# Altium schematic sheet ARK_CAN_GPS_Microcontroller.SchDoc(ARK_CAN_GPS_Microcontroller)
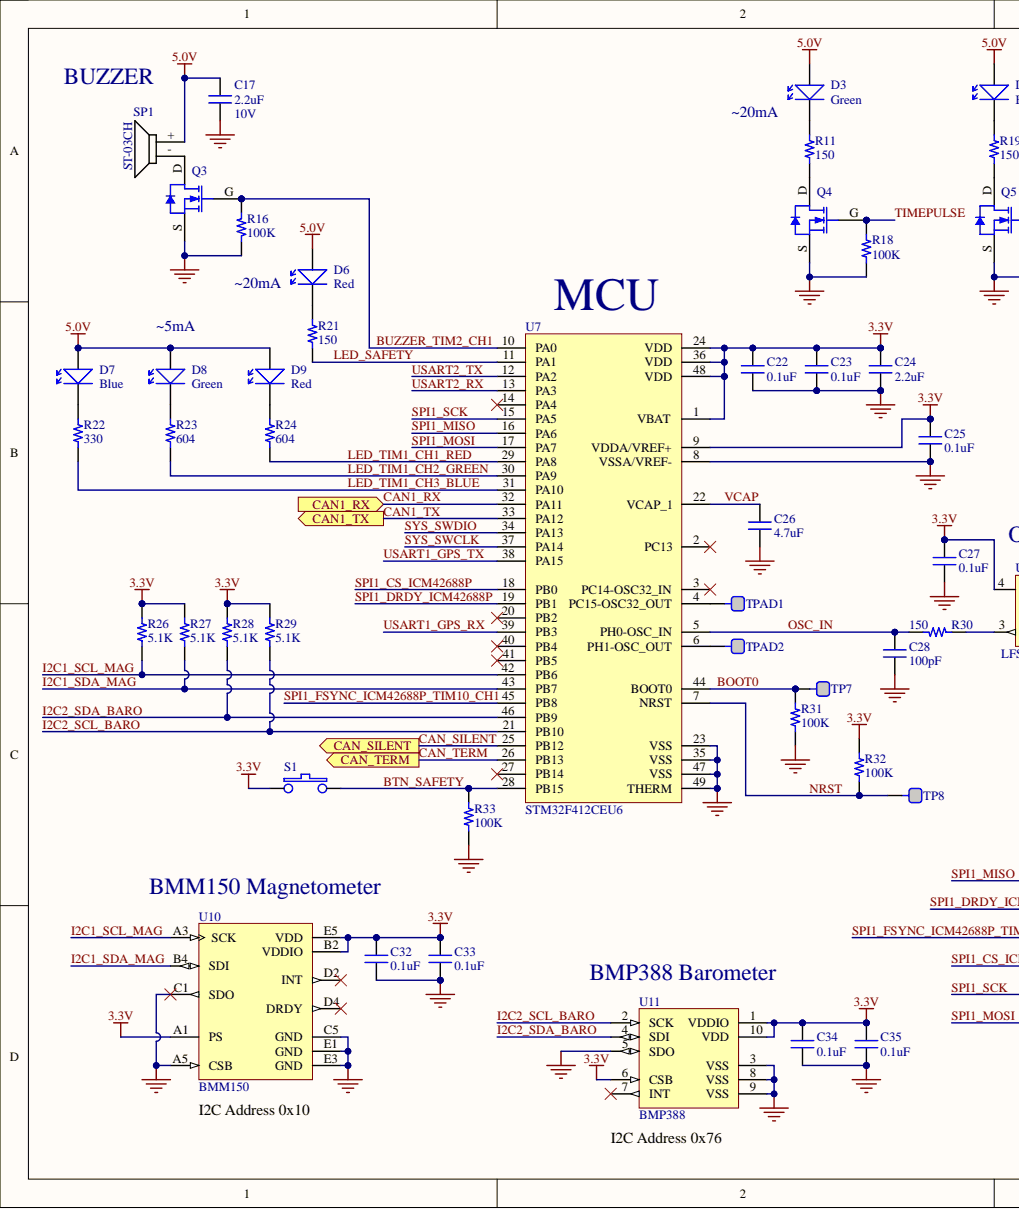
[diagram p0: ARK_CAN_GPS_Microcontroller.SchDoc, left]
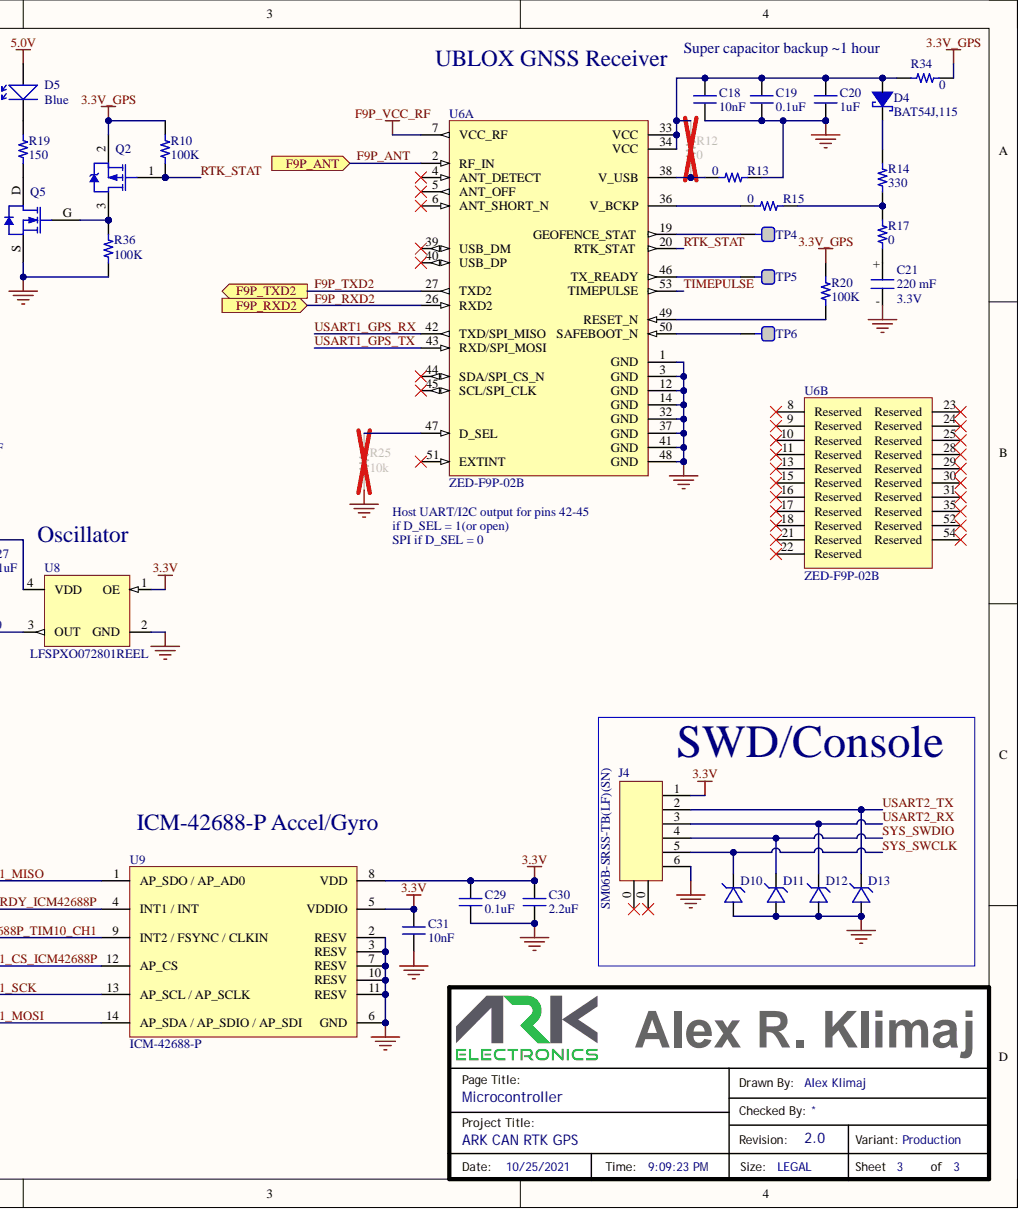
[diagram p1: ARK_CAN_GPS_Microcontroller.SchDoc, right]

## Parts
C17  2.2uF  Voltage_Rating: 10V  [CAP 0402/1005]
C18  value 10nF  [CAP 0402/1005]
C19  value 0.1uF  [CAP 0402/1005]
C20  1uF  [CAP 0402/1005]
C21  220 mF  Voltage_Rating: 3.3V  [DSK-3R3H224U-HL]
C22  value 0.1uF  [CAP 0402/1005]
C23  value 0.1uF  [CAP 0402/1005]
C24  2.2uF  [CAP 0402/1005]
C25  value 0.1uF  [CAP 0402/1005]
C26  4.7uF  [CAP 0402/1005]
C27  value 0.1uF  [CAP 0402/1005]
C28  100pF  [CAP 0402/1005]
C29  value 0.1uF  [CAP 0402/1005]
C30  2.2uF  [CAP 0402/1005]
C31  value 10nF  [CAP 0402/1005]
C32  value 0.1uF  [CAP 0402/1005]
C33  value 0.1uF  [CAP 0402/1005]
C34  value 0.1uF  [CAP 0402/1005]
C35  value 0.1uF  [CAP 0402/1005]
D3  LTST-C191KGKT  [LTST-C191TBKT]  (value not drawn)
D4  value BAT54J,115  Manufacturer Part Number: BAT54J,115  [SOD323]
D5  LTST-C194TBKT  [LTST-C191TBKT]  (value not drawn)
D6  LTST-C190KRKT  [LTST-C191TBKT]  (value not drawn)
D7  LTST-C194TBKT  [LTST-C191TBKT]  (value not drawn)
D8  LTST-C191KGKT  [LTST-C191TBKT]  (value not drawn)
D9  LTST-C190KRKT  [LTST-C191TBKT]  (value not drawn)
D10  (no value)  [SOD-923]
D11  (no value)  [SOD-923]
D12  (no value)  [SOD-923]
D13  (no value)  [SOD-923]
J4  value SM06B-SRSS-TB(LF)(SN)  [SM06B-SRSS-TB(LF)(SN)]
Q2  (no value)  [INF-PG-SOT-323_L]
Q3  DMN53D0L-7  [SOT23 DIODES]  (value not drawn)
Q4  DMN53D0L-7  [SOT23 DIODES]  (value not drawn)
Q5  DMN53D0L-7  [SOT23 DIODES]  (value not drawn)
R10  100K  [RESC0402(1005)_N]
R11  150  [RESC0402(1005)_N]
R12  0  [RESC0402(1005)_N]
R13  0  [RESC0402(1005)_N]
R14  330  [RESC0402(1005)_N]
R15  0  [RESC0402(1005)_N]
R16  100K  [RESC0402(1005)_N]
R17  0  [RESC0402(1005)_N]
R18  100K  [RESC0402(1005)_N]
R19  150  [RESC0402(1005)_N]
R20  100K  [RESC0402(1005)_N]
R21  150  [RESC0402(1005)_N]
R22  330  [RESC0402(1005)_N]
R23  604  [RESC0402(1005)_N]
R24  604  [RESC0402(1005)_N]
R25  value 10k  [RESC0402(1005)_N]
R26  value 5.1K  [RESC0402(1005)_N]
R27  value 5.1K  [RESC0402(1005)_N]
R28  value 5.1K  [RESC0402(1005)_N]
R29  value 5.1K  [RESC0402(1005)_N]
R30  150  [RESC0402(1005)_N]
R31  100K  [RESC0402(1005)_N]
R32  100K  [RESC0402(1005)_N]
R33  100K  [RESC0402(1005)_N]
R34  0  [RESC0402(1005)_N]
R36  100K  [RESC0402(1005)_N]
S1  PTS 647 SK38 SMTR2 LFS  [PTS 647 SK38 SMTR2 LFS]  (value not drawn)
SP1  ST-03CH  [ST-03CH]
TP4  (no value)  [TEST_PAD]  (no BOM)
TP5  (no value)  [TEST_PAD]  (no BOM)
TP6  (no value)  [TEST_PAD]  (no BOM)
TP7  (no value)  [TEST_PAD]  (no BOM)
TP8  (no value)  [TEST_PAD]  (no BOM)
TPAD1  (no value)  [TEST_PAD]  (no BOM)
TPAD2  (no value)  [TEST_PAD]  (no BOM)
U6  ZED-F9P-02B  [Ublox ZED-F9P]  (parts A,B)
U7  STM32F412CEU6  [UFQFPN48]
U8  LFSPXO072801REEL  Voltage_Rating: 3.3V  [LFSPXO072801REEL]
U9  ICM-42688-P  [ICM-42688-P]
U10  BMM150  [XDCR_BMM150]
U11  BMP388  [BMP388]

## Ports
CAN1_RX, CAN1_TX, CAN_SILENT, CAN_TERM, F9P_ANT, F9P_RXD2, F9P_TXD2

## Nets
3.3V: C22.2 C23.2 C24.2 C25.2 C27.2 C29.2 C30.2 C31.2 C32.2 C33.2 C34.2 C35.2 J4.1 R26.2 R27.2 R28.2 R29.2 R32.2 S1.2 U7.1(VBAT) U7.9(VDDA/VREF+) U7.24(VDD) U7.36(VDD) U7.48(VDD) U8.1(OE) U8.4(VDD) U9.5(VDDIO) U9.8(VDD) U10.A1(PS) U10.B2(VDDIO) U10.E5(VDD) U11.1(VDDIO) U11.6(CSB) U11.10(VDD)
3.3V_GPS: Q2.2 R10.2 R20.2 R34.1
5.0V: C17.2 D3.2 D5.2 D6.2 D7.2 D8.2 D9.2 SP1.+
F9P_VCC_RF: U6.7(VCC_RF)
GND: C17.1 C18.1 C19.1 C20.1 C21.- C22.1 C23.1 C24.1 C25.1 C26.1 C27.1 C28.1 C29.1 C30.1 C31.1 C32.1 C33.1 C34.1 C35.1 D10.2 D11.2 D12.2 D13.2 J4.6 Q3.S Q4.S Q5.S R13.2 R16.2 R18.1 R25.1 R31.1 R33.1 R36.1 U6.1(GND) U6.3(GND) U6.12(GND) U6.14(GND) U6.32(GND) U6.37(GND) U6.41(GND) U6.48(GND) U7.8(VSSA/VREF-) U7.23(VSS) U7.35(VSS) U7.47(VSS) U7.49(THERM) U8.2(GND) U9.2(RESV) U9.3(RESV) U9.6(GND) U9.7(RESV) U9.10(RESV) U9.11(RESV) U10.A5(CSB) U10.C1(SDO) U10.C5(GND) U10.E1(GND) U10.E3(GND) U11.3(VSS) U11.5(SDO) U11.8(VSS) U11.9(VSS)
BOOT0: R31.2 TP7.1 U7.44(BOOT0)
BTN_SAFETY: R33.2 S1.1 U7.28(PB15)
BUZZER_TIM2_CH1: Q3.G R16.1 U7.10(PA0)
CAN1_RX: U7.32(PA11) ; port CAN1_RX
CAN1_TX: U7.33(PA12) ; port CAN1_TX
CAN_SILENT: U7.25(PB12) ; port CAN_SILENT
CAN_TERM: U7.26(PB13) ; port CAN_TERM
F9P_ANT: U6.2(RF_IN) ; port F9P_ANT
F9P_RXD2: U6.26(RXD2) ; port F9P_RXD2
F9P_TXD2: U6.27(TXD2) ; port F9P_TXD2
I2C1_SCL_MAG: R26.1 U7.42(PB6) U10.A3(SCK)
I2C1_SDA_MAG: R27.1 U7.43(PB7) U10.B4(SDI)
I2C2_SCL_BARO: R29.1 U7.21(PB10) U11.2(SCK)
I2C2_SDA_BARO: R28.1 U7.46(PB9) U11.4(SDI)
LED_SAFETY: R21.1 U7.11(PA1)
LED_TIM1_CH1_RED: R24.1 U7.29(PA8)
LED_TIM1_CH2_GREEN: R23.1 U7.30(PA9)
LED_TIM1_CH3_BLUE: R22.1 U7.31(PA10)
NRST: R32.1 TP8.1 U7.7(NRST)
OSC_IN: C28.2 R30.1 U7.5(PH0-OSC_IN)
RTK_STAT: Q2.1 R10.1 U6.20(RTK_STAT)
SPI1_CS_ICM42688P: U7.18(PB0) U9.12(AP_CS)
SPI1_DRDY_ICM42688P: U7.19(PB1) U9.4(INT1_/_INT)
SPI1_FSYNC_ICM42688P_TIM10_CH1: U7.45(PB8) U9.9
SPI1_MISO: U7.16(PA6) U9.1(AP_SDO_/_AP_AD0)
SPI1_MOSI: U7.17(PA7) U9.14
SPI1_SCK: U7.15(PA5) U9.13(AP_SCL_/_AP_SCLK)
SYS_SWCLK: D10.1 J4.5 U7.37(PA14)
SYS_SWDIO: D11.1 J4.4 U7.34(PA13)
TIMEPULSE: Q4.G R18.2 U6.53(TIMEPULSE)
USART1_GPS_RX: U6.42(TXD/SPI_MISO) U7.39(PB3)
USART1_GPS_TX: U6.43(RXD/SPI_MOSI) U7.38(PA15)
USART2_RX: D12.1 J4.3 U7.13(PA3)
USART2_TX: D13.1 J4.2 U7.12(PA2)
VCAP: C26.2 U7.22(VCAP_1)
NetC18_2: C18.2 C19.2 C20.2 D4.2 R12.2 R34.2 U6.33(VCC) U6.34(VCC)
NetC21_+: C21.+ R17.1
NetD3_1: D3.1 R11.2
NetD4_1: D4.1 R14.2
NetD5_1: D5.1 R19.2
NetD6_1: D6.1 R21.2
NetD7_1: D7.1 R22.2
NetD8_1: D8.1 R23.2
NetD9_1: D9.1 R24.2
NetQ2_3: Q2.3 Q5.G R36.2
NetQ3_D: Q3.D SP1.-
NetQ4_D: Q4.D R11.1
NetQ5_D: Q5.D R19.1
NetR12_1: R12.1 R13.1 U6.38(V_USB)
NetR14_1: R14.1 R15.2 R17.2
NetR15_1: R15.1 U6.36(V_BCKP)
NetR20_1: R20.1 U6.49(RESET_N)
NetR25_2: R25.2 U6.47(D_SEL)
NetR30_2: R30.2 U8.3(OUT)
NetTP4_1: TP4.1 U6.19(GEOFENCE_STAT)
NetTP5_1: TP5.1 U6.46(TX_READY)
NetTP6_1: TP6.1 U6.50(SAFEBOOT_N)
NetTPAD1_1: TPAD1.1 U7.4(PC15-OSC32_OUT)
NetTPAD2_1: TPAD2.1 U7.6(PH1-OSC_OUT)

Not connected: J4 0; U6 4(ANT_DETECT) 5(ANT_OFF) 6(ANT_SHORT_N) 8(Reserved) 9(Reserved) 10(Reserved) 11(Reserved) 13(Reserved) 15(Reserved) 16(Reserved) 17(Reserved) 18(Reserved) 21(Reserved) 22(Reserved) 23(Reserved) 24(Reserved) 25(Reserved) 28(Reserved) 29(Reserved) 30(Reserved) 31(Reserved) 35(Reserved) 39(USB_DM) 40(USB_DP) 44(SDA/SPI_CS_N) 45(SCL/SPI_CLK) 51(EXTINT) 52(Reserved) 54(Reserved); U7 2(PC13) 3(PC14-OSC32_IN) 14(PA4) 20(PB2) 27(PB14) 40(PB4) 41(PB5); U10 D2(INT) D4(DRDY); U11 7(INT)

## Library data of the parts
C17 = 30151: SMT Capacitor; Manufacturer: Murata; Manufacturer Alt 1: Taiyo Yuden; Mfr Part Description: CAP CER 2.2uF ±20% 10V X5R 0402 (1005 Metric); Mfr Part Number: GRM155R61A225ME95D; Mfr Part Number Alt 1: LMK105BJ225MV-F; Database Table Name: Parts; Dielectric: X5R; Tolerance: ±20%; Updated By: aklimaj; Component Kind: Standard; ComponentLink1Description: Datasheet; ComponentLink1URL: http://search.murata.co.jp/Ceramy/image/img/A01X/partnumbering_e_01.pdf; ComponentLink2Description: Supplier Part Details; ComponentLink2URL: https://www.digikey.com/product-detail/en/murata-electronics-north-america/GRM155R61A225ME95D/490-10452-2-ND/5027537; Created_Date: 2017/11/02 14:41:38; ID: 30151; Library Name: Parts.DbLib; LifeCycle: New; Package_Case: 0402 (1005 Metric); Part_Type: Capacitor; RoHS: Yes; Supplier: Digi-Key; Supplier Part Number: 490-10452-2-ND; Temperature Range: -55C to +85C; Updated_Date: 2021/09/10 21:38:25; Value: 2.2uF; Created By: aklimaj
C18 C31 = 30018: SMT Capacitor; Manufacturer: Samsung; Mfr Part Description: CAP CER 10000pF ±10% 25V X7R 0402 (1005 Metric); Mfr Part Number: CL05B103KA5NNNC; Database Table Name: Parts; Dielectric: X7R; Tolerance: ±10%; Updated By: aklimaj; Voltage_Rating: 25V; Component Kind: Standard; ComponentLink1Description: Datasheet; ComponentLink1URL: http://www.samsungsem.com/kr/support/product-search/mlcc/__icsFiles/afieldfile/2016/08/18/S_CL05B103KA5NNNC.pdf; ComponentLink2Description: Supplier Part Details; ComponentLink2URL: https://www.digikey.com/product-detail/en/samsung-electro-mechanics-america-inc/CL05B103KA5NNNC/1276-1057-1-ND/3889143; Created_Date: 2017/07/13 10:07:08; ID: 30018; Library Name: Parts.DbLib; LifeCycle: New; Package_Case: 0402 (1005 Metric); Part_Type: Capacitor; RoHS: Yes; Supplier: Digi-Key; Supplier Part Number: 1276-1057-1-ND; Temperature Range: -55C to +125C; Updated_Date: 2017/07/13 10:07:58; Created By: aklimaj
C19 C22 C23 C25 C27 C29 C32-C35 = 30007: SMT Capacitor; Manufacturer: Walsin Technology Corporation; Mfr Part Description: CAP CER 0.1uF ±10% 25V X5R 0402 (1005 Metric); Mfr Part Number: 0402X104K250CT; Database Table Name: Parts; Dielectric: X5R; Tolerance: ±10%; Updated By: aklimaj; Voltage_Rating: 25V; Component Kind: Standard; ComponentLink1Description: Datasheet; ComponentLink1URL: http://www.passivecomponent.com/wp-content/uploads/2018/10/MLCC.pdf; ComponentLink2Description: Supplier Part Details; ComponentLink2URL: https://www.digikey.com/products/en?keywords=0402X104K250CT; Created_Date: 2017/07/11 17:31:38; ID: 30007; Library Name: Parts.DbLib; LifeCycle: New; Package_Case: 0402 (1005 Metric); Part_Type: Capacitor; RoHS: Yes; Supplier: Digi-Key; Supplier Part Number: 1292-1640-2-ND; Temperature Range: -55C to +85C; Updated_Date: 2020/12/17 20:30:00; Created By: aklimaj
C20 = 30652: SMT Capacitor; Manufacturer: Samsung Electro-Mechanics; Mfr Part Description: CAP CER 1uF ±10% 10V X5R 0402 (1005 Metric); Mfr Part Number: CL05A105KP5NNNC; Database Table Name: Parts; Dielectric: X5R; Tolerance: ±10%; Updated By: aklimaj; Voltage_Rating: 10V; Component Kind: Standard; ComponentLink1Description: Datasheet; ComponentLink1URL: http://www.samsungsem.com/kr/support/product-search/mlcc/CL05A105KP5NNNC.jsp; ComponentLink2Description: Supplier Part Details; ComponentLink2URL: https://www.digikey.com/product-detail/en/0/1276-1076-1-ND; Created_Date: 2019/11/21 13:38:55; ID: 30652; Library Name: Parts.DbLib; LifeCycle: New; Package_Case: 0402 (1005 Metric); Part_Type: Capacitor; RoHS: Yes; Supplier: Digi-Key; Supplier Part Number: 1276-1076-1-ND; Temperature Range: -55C to +85C; Updated_Date: 2020/08/31 12:38:02; Value: 1uF; Created By: aklimaj
C21 = 31092: Manufacturer: Elna America; Mfr Part Description: CAP 220 mF -20%, +80% 3.3V Coin, Wide Terminals - Opposite Sides; Part_Type: Capacitor; Database Table Name: Parts; Tolerance: -20%, +80%; Updated By: aklimaj; Component Kind: Standard; ComponentLink1Description: Datasheet; ComponentLink1URL: https://media.digikey.com/pdf/Data%20Sheets/Elna%20America%20Inc/DS,DSK.pdf; ComponentLink2Description: Supplier Part Details; ComponentLink2URL: https://www.digikey.com/en/products/detail/elna-america/DSK-3R3H224U-HL/970181?s=N4IgTCBcDaIGwAYAsBaAjAsD0oHIBEQBdAXyA; Created_Date: 2021/05/23 17:43:09; ID: 31092; Library Name: Parts.DbLib; LifeCycle: New; Mfr Part Number: DSK-3R3H224U-HL; Package_Case: Coin, Wide Terminals - Opposite Sides; RoHS: Yes; Supplier: Digi-Key; Supplier Part Number: 604-1020-2-ND; Temperature Range: -10C to +60C; Updated_Date: 2021/05/23 18:11:51; Value: 220 mF; Created By: aklimaj
C24 C30 = 30151: SMT Capacitor; Manufacturer: Murata; Manufacturer Alt 1: Taiyo Yuden; Mfr Part Description: CAP CER 2.2uF ±20% 10V X5R 0402 (1005 Metric); Mfr Part Number: GRM155R61A225ME95D; Mfr Part Number Alt 1: LMK105BJ225MV-F; Database Table Name: Parts; Dielectric: X5R; Tolerance: ±20%; Updated By: aklimaj; Voltage_Rating: 10V; Component Kind: Standard; ComponentLink1Description: Datasheet; ComponentLink1URL: http://search.murata.co.jp/Ceramy/image/img/A01X/partnumbering_e_01.pdf; ComponentLink2Description: Supplier Part Details; ComponentLink2URL: https://www.digikey.com/product-detail/en/murata-electronics-north-america/GRM155R61A225ME95D/490-10452-2-ND/5027537; Created_Date: 2017/11/02 14:41:38; ID: 30151; Library Name: Parts.DbLib; LifeCycle: New; Package_Case: 0402 (1005 Metric); Part_Type: Capacitor; RoHS: Yes; Supplier: Digi-Key; Supplier Part Number: 490-10452-2-ND; Temperature Range: -55C to +85C; Updated_Date: 2021/09/10 21:38:25; Value: 2.2uF; Created By: aklimaj
C26 = 30302: SMT Capacitor; Manufacturer: TDK Corporation; Manufacturer Alt 1: Venkel; Mfr Part Description: CAP CER 4.7uF ±10% 6.3V X5R 0402 (1005 Metric); Mfr Part Number: C1005X5R0J475K050BC; Mfr Part Number Alt 1: C0402X5R6R3-475KNP-CT; Database Table Name: Parts; Dielectric: X5R; Tolerance: ±10%; Updated By: aklimaj; Voltage_Rating: 6.3V; Component Kind: Standard; ComponentLink1Description: Datasheet; ComponentLink1URL: https://product.tdk.com/info/en/catalog/datasheets/mlcc_commercial_general_en.pdf; ComponentLink2Description: Supplier Part Details; ComponentLink2URL: https://www.digikey.com/products/en?keywords=445-5947-1-ND&stock=1; Created_Date: 2019/02/28 17:07:10; ID: 30302; Library Name: Parts.DbLib; LifeCycle: New; Manufacturer Alt 2: AVX Corporation; Mfr Part Number Alt 2: 04026D475KAT2A; Package_Case: 0402 (1005 Metric); Part_Type: Capacitor; RoHS: Yes; Supplier: Digi-Key; Supplier Part Number: 445-5947-1-ND; Temperature Range: -55C to +85C; Updated_Date: 2021/08/05 14:56:58; Value: 4.7uF; Created By: aklimaj
C28 = 30502: SMT Capacitor; Manufacturer: Samsung Electro-Mechanics; Mfr Part Description: CAP CER 100pF ±5% 50V NPO 0402 (1005 Metric); Mfr Part Number: CL05C101JB5NNNC; Database Table Name: Parts; Dielectric: NPO; Tolerance: ±5%; Updated By: aklimaj; Voltage_Rating: 50V; Component Kind: Standard; ComponentLink1Description: Datasheet; ComponentLink1URL: http://www.samsungsem.com/kr/support/product-search/mlcc/CL05C101JB5NNNC.jsp; ComponentLink2Description: Supplier Part Details; ComponentLink2URL: https://www.digikey.com/product-detail/en/samsung-electro-mechanics/CL05C101JB5NNNC/1276-1025-1-ND/3889111; Created_Date: 2019/07/13 19:13:16; ID: 30502; Library Name: Parts.DbLib; LifeCycle: New; Package_Case: 0402 (1005 Metric); Part_Type: Capacitor; RoHS: Yes; Supplier: Digi-Key; Supplier Part Number: 1276-1025-1-ND; Temperature Range: -55C to +125C; Updated_Date: 2021/09/10 11:27:15; Value: 100pF; Created By: aklimaj
D3 D8 = 30628: LTST-C191TBKT LED BLUE CLEAR 0603 SMD; Manufacturer: Lite-On Inc.; Manufacturer Alt 1: Wurth; Mfr Part Description: Green 571nm LED Indication - Discrete 2V 0603 (1608 Metric); Mfr Part Number Alt 1: 150060VS75000; Part_Type: Discrete; Current_Rating: 20mA; Database Table Name: Parts; Updated By: aklimaj; Voltage_Rating: 2V; Component Kind: Standard; ComponentLink1Description: Datasheet; ComponentLink1URL: http://optoelectronics.liteon.com/upload/download/DS22-2000-228/LTST-C191KGKT.PDF; ComponentLink2Description: Supplier Part Details; ComponentLink2URL: https://www.digikey.com/product-detail/en/lite-on-inc/LTST-C191KGKT/160-1446-1-ND/386834; Created_Date: 2019/11/13 17:03:39; ID: 30628; Library Name: Parts.DbLib; LifeCycle: New; Mfr Part Number: LTST-C191KGKT; Package_Case: 0603 (1608 Metric); RoHS: Yes; Supplier: Digi-Key; Supplier Part Number: 160-1446-1-ND; Updated_Date: 2021/03/27 12:39:10; Value: LTST-C191KGKT; Created By: aklimaj
D4 = 30022: Diode Schottky 30V 200mA (DC) Surface Mount SOD-323F; Manufacturer: Nexperia USA Inc.; Mfr Part Description: DIODE SCHOTTKY 30V 200MA SOD323F; Part_Type: Discrete; Capacitance @ Vr, F: 10pF @ 1V, 1MHz; Current - Reverse Leakage @ Vr: 2µA @ 25V; Database Table Name: Parts; Speed: Small Signal =< 200mA (Io), Any Speed; Updated By: aklimaj; Voltage - Forward (Vf) (Max) @ If: 800mV @ 100mA; Category: Discrete Semiconductor Products; Component Kind: Standard; ComponentLink1Description: Datasheet; ComponentLink1URL: http://assets.nexperia.com/documents/data-sheet/BAT54J.pdf; ComponentLink2Description: Supplier Part Details; ComponentLink2URL: https://www.digikey.com/products/en?keywords=1727-4818-6-ND&stock=1; Created_Date: 2017/07/13 11:14:24; Current - Average Rectified (Io): 200mA (DC); Current_Rating: 200mA (DC); Diode Type: Schottky; ID: 30022; Library Name: Parts.DbLib; LifeCycle: New; Mfr Part Number: BAT54J,115; Mounting Type: Surface Mount; Operating Temperature - Junction: 150°C (Max); Package / Case: SC-90, SOD-323F; Package_Case: SC-90, SOD-323F; Packaging: Digi-Reel®; Part Status: Active; Pricing 1: 1=0.37, 10=0.273, 100=0.1545, 500=0.1023, 1000=0.07843 (USD); RoHS: Yes; Stock 1: 25340; Supplier: Digi-Key; Supplier 1: Digi-Key; Supplier Device Package: SOD-323F; Supplier Part Number: 1727-4818-6-ND; Supplier Part Number 1: 1727-4818-6-ND; Temperature Range: 150C (Max); Updated_Date: 2017/07/13 11:17:36; Voltage - DC Reverse (Vr) (Max): 30V; Voltage_Rating: 30V; Created By: aklimaj
D5 D7 = 30059: LTST-C191TBKT LED BLUE CLEAR 0603 SMD; Manufacturer: Lite-On Inc.; Mfr Part Description: LED BLUE CLEAR SMD; Part_Type: Discrete; Current_Rating: 20mA; Database Table Name: Parts; Notes: Was Mfr P/N LTST-C191TBKT; Updated By: aklimaj; Voltage_Rating: 3.3V; Component Kind: Standard; ComponentLink1Description: Datasheet; ComponentLink1URL: https://optoelectronics.liteon.com/upload/download/DS22-2010-0025/LTST-C194TBKT.PDF; ComponentLink2Description: Supplier Part Details; ComponentLink2URL: https://www.digikey.com/products/en?keywords=LTST-C194TBKT; Created_Date: 2017/09/05 17:40:57; ID: 30059; Library Name: Parts.DbLib; LifeCycle: New; Mfr Part Number: LTST-C194TBKT; Package_Case: 0603 (1608 Metric); RoHS: Yes; Supplier: Digi-Key; Supplier Part Number: 160-1837-2-ND; Updated_Date: 2021/09/10 21:26:14; Value: LTST-C194TBKT; Created By: aklimaj
D6 D9 = 30943: Manufacturer: Lite-On Inc.; Manufacturer Alt 1: Everlight; Mfr Part Description: LED RED CLEAR CHIP SMD; Mfr Part Number Alt 1: EAST16084RA0; Part_Type: Discrete; Current_Rating: 20mA; Database Table Name: Parts; Updated By: aklimaj; Voltage_Rating: 2V; Component Kind: Standard; ComponentLink1Description: Datasheet; ComponentLink1URL: http://optoelectronics.liteon.com/upload/download/DS-22-99-0151/LTST-C190KRKT.pdf; ComponentLink2Description: Supplier Part Details; ComponentLink2URL: https://www.digikey.com/products/en?keywords=LTST-C190KRKT; Created_Date: 2020/12/11 17:10:57; ID: 30943; Library Name: Parts.DbLib; LifeCycle: New; Manufacturer Alt 2: Lite-On Inc.; Mfr Part Number: LTST-C190KRKT; Mfr Part Number Alt 2: LTST-C191KRKT; Package_Case: 0603 (1608 Metric); RoHS: Yes; Supplier: Digi-Key; Supplier Part Number: 160-1436-2-ND; Updated_Date: 2021/04/09 09:54:26; Value: LTST-C190KRKT; Created By: aklimaj
D10-D13 = 30166: TVS Diode; Manufacturer: ON Semiconductor; Mfr Part Description: TVS DIODE 3.3VWM SOD923; Mfr Part Number: ESD9C3.3ST5G; Part_Type: Protection; Database Table Name: Parts; Updated By: aklimaj; Value: ESD9C3.3ST5G; Voltage_Rating: 3.3V (Max); Component Kind: Standard; ComponentLink1Description: Datasheet; ComponentLink1URL: http://www.onsemi.com/pub/Collateral/ESD9C3.3S-D.PDF; ComponentLink2Description: Supplier Part Details; ComponentLink2URL: https://www.digikey.com/product-detail/en/on-semiconductor/ESD9C3.3ST5G/ESD9C3.3ST5GOSCT-ND/1646498; Created_Date: 2017/12/13 10:54:56; ID: 30166; Library Name: Parts.DbLib; LifeCycle: New; Package_Case: SOD-923; RoHS: Yes; Supplier: Digi-Key; Supplier Part Number: ESD9C3.3ST5GOSCT-ND; Temperature Range: -55C to +150C (TJ); Updated_Date: 2018/10/19 21:38:12; Created By: aklimaj
J4 = 30085: CONN HEADER SH 6POS SIDE 1MM TIN; Manufacturer: JST Sales America Inc.; Part_Type: Connector; Current_Rating: 0.7A; Database Table Name: Parts; Updated By: aklimaj; Voltage_Rating: 50V; Component Kind: Standard; ComponentLink1Description: Datasheet; ComponentLink1URL: http://www.jst-mfg.com/product/pdf/eng/eSH.pdf; ComponentLink2Description: Supplier Part Details; ComponentLink2URL: https://www.digikey.com/product-detail/en/jst-sales-america-inc/SM06B-SRSS-TB(LF)(SN)/455-1806-1-ND/926877; Created_Date: 2017/09/13 10:26:52; ID: 30085; Library Name: Parts.DbLib; LifeCycle: New; Mfr Part Description: CONN HEADER SH 6POS SIDE 1MM TIN; Mfr Part Number: SM06B-SRSS-TB(LF)(SN); RoHS: Yes; Supplier: Digi-Key; Supplier Part Number: 455-1806-1-ND; Temperature Range: -25C to +85C; Updated_Date: 2018/10/19 21:38:32; Created By: aklimaj
Q2 = 30108: INF-PEMOS-GSD-3-B; Manufacturer: Infineon Technologies; Mfr Part Description: MOSFET P-CH 20V 390MA SOT-323; Mfr Part Number: BSS223PWH6327XTSA1; Part_Type: Discrete; Current_Rating: 390mA (Ta); Database Table Name: Parts; Updated By: aklimaj; Value: BSS223PWH6327XTSA1; Voltage_Rating: 20V; Component Kind: Standard; ComponentLink1Description: Datasheet; ComponentLink1URL: http://www.infineon.com/dgdl/Infineon-BSS223PW-DS-v01_05-en.pdf?fileId=db3a3043321e49940132481523b6245f; ComponentLink2Description: Supplier Part Details; ComponentLink2URL: https://www.digikey.com/products/en?keywords=BSS223PWH6327XTSA1CT-ND&stock=1; Created_Date: 2017/09/21 14:50:09; ID: 30108; Library Name: Parts.DbLib; LifeCycle: New; Package_Case: SC-70, SOT-323; RoHS: Yes; Supplier: Digi-Key; Supplier Part Number: BSS223PWH6327XTSA1CT-ND; Temperature Range: -55C to +150C (TJ); Updated_Date: 2019/12/11 11:26:00; Created By: aklimaj
Q3-Q5 = 31111: Manufacturer: Diodes Incorporated; Mfr Part Description: MOSFET N-CH 50V 500MA SOT23; Part_Type: Discrete; Current_Rating: 500mA (Ta); Database Table Name: Parts; Updated By: aklimaj; Voltage_Rating: 2.5V, 10V; Component Kind: Standard; ComponentLink1Description: Datasheet; ComponentLink1URL: https://www.diodes.com/assets/Datasheets/DMN53D0L.pdf; ComponentLink2Description: Supplier Part Details; ComponentLink2URL: https://www.digikey.com/en/products/detail/diodes-incorporated/DMN53D0L-7/4934983; Created_Date: 2021/07/13 17:36:24; ID: 31111; Library Name: Parts.DbLib; LifeCycle: New; Mfr Part Number: DMN53D0L-7; Package_Case: TO-236-3, SC-59, SOT-23-3; RoHS: Yes; Supplier: Digi-Key; Supplier Part Number: DMN53D0L-7DITR-ND; Temperature Range: -55C to +150C (TJ); Updated_Date: 2021/07/13 17:36:30; Value: DMN53D0L-7; Created By: aklimaj
R10 R16 R18 R20 R31-R33 R36 = 30333: SMT Resistor; Manufacturer: Yageo; Mfr Part Description: RES 100K Ohm ±5% 1/16W 0402 (1005 Metric); Mfr Part Number: AC0402JR-07100KL; Database Table Name: Parts; Power_Rating: 0.063W, 1/16W; Temperature Coeff: ±100ppm/degC; Tolerance: ±5%; Updated By: aklimaj; Component Kind: Standard; ComponentLink1Description: Datasheet; ComponentLink1URL: http://www.yageo.com/NewPortal/yageodocoutput?fileName=/pdf/R-Chip/PYu-AC_51_RoHS_L_6.pdf; ComponentLink2Description: Supplier Part Details; ComponentLink2URL: https://www.digikey.com/products/en?keywords=YAG3515CT-ND&stock=1; Created_Date: 2019/02/28 18:55:55; ID: 30333; Library Name: Parts.DbLib; LifeCycle: New; Package_Case: 0402 (1005 Metric); Part_Type: Resistor; RoHS: Yes; Supplier: Digi-Key; Supplier Part Number: YAG3515CT-ND; Temperature Range: -55C to +155C; Updated_Date: 2019/02/28 18:56:10; Value: 100K; Created By: aklimaj
R11 R19 R21 R30 = 30328: SMT Resistor; Manufacturer: Yageo; Mfr Part Description: RES 150 Ohm ±1% 1/16W 0402 (1005 Metric); Mfr Part Number: RC0402FR-07150RL; Database Table Name: Parts; Power_Rating: 0.063W, 1/16W; Temperature Coeff: ±100ppm/degC; Tolerance: ±1%; Updated By: aklimaj; Component Kind: Standard; ComponentLink1Description: Datasheet; ComponentLink1URL: https://www.yageo.com/upload/media/product/productsearch/datasheet/rchip/PYu-RC_Group_51_RoHS_L_11.pdf; ComponentLink2Description: Supplier Part Details; ComponentLink2URL: https://www.digikey.com/en/products/detail/yageo/RC0402FR-07150RL/726543; Created_Date: 2019/02/28 18:44:46; ID: 30328; Library Name: Parts.DbLib; LifeCycle: New; Package_Case: 0402 (1005 Metric); Part_Type: Resistor; RoHS: Yes; Supplier: Digi-Key; Supplier Part Number: 311-150LRTR-ND; Temperature Range: -55C to +155C; Updated_Date: 2021/05/26 09:40:11; Value: 150; Created By: aklimaj
R12 R13 R15 R17 R34 = 30327: SMT Resistor; Manufacturer: Yageo; Manufacturer Alt 1: Panasonic Electronic Components; Mfr Part Description: RES 0 Ohm Jumper 1/16W 0402 (1005 Metric); Mfr Part Number: AC0402JR-070RL; Mfr Part Number Alt 1: ERJ-2GE0R00X; Database Table Name: Parts; Power_Rating: 0.063W, 1/16W; Tolerance: Jumper; Updated By: aklimaj; Component Kind: Standard; ComponentLink1Description: Datasheet; ComponentLink1URL: https://www.yageo.com/upload/media/product/productsearch/datasheet/rchip/PYu-AC_51_RoHS_L_7.pdf; ComponentLink2Description: Supplier Part Details; ComponentLink2URL: https://www.digikey.com/product-detail/en/AC0402JR-070RL/311-0.0LBCT-ND; Created_Date: 2019/02/28 18:42:38; ID: 30327; Library Name: Parts.DbLib; LifeCycle: New; Manufacturer Alt 2: Stackpole Electronics Inc; Mfr Part Number Alt 2: RMCF0402ZT0R00; Package_Case: 0402 (1005 Metric); Part_Type: Resistor; RoHS: Yes; Supplier: Digi-Key; Supplier Part Number: 311-0.0LBCT-ND; Temperature Range: -55C to +155C; Updated_Date: 2021/07/12 11:57:52; Value: 0; Created By: aklimaj
R14 R22 = 30681: SMT Resistor; Manufacturer: Yageo; Mfr Part Description: RES 330 Ohm ±1% 1/16W 0402 (1005 Metric); Mfr Part Number: RC0402FR-07330RL; Database Table Name: Parts; Power_Rating: 0.063W, 1/16W; Temperature Coeff: ±100ppm/degC; Tolerance: ±1%; Updated By: aklimaj; Component Kind: Standard; ComponentLink1Description: Datasheet; ComponentLink1URL: https://www.yageo.com/upload/media/product/productsearch/datasheet/rchip/PYu-RC_Group_51_RoHS_L_10.pdf; ComponentLink2Description: Supplier Part Details; ComponentLink2URL: https://www.digikey.com/product-detail/en/yageo/RC0402FR-07330RL/311-330LRCT-ND/729541; Created_Date: 2019/12/02 20:26:12; ID: 30681; Library Name: Parts.DbLib; LifeCycle: New; Package_Case: 0402 (1005 Metric); Part_Type: Resistor; RoHS: Yes; Supplier: Digi-Key; Supplier Part Number: 311-330LRCT-ND; Temperature Range: -55C to +155C; Updated_Date: 2019/12/02 20:28:13; Value: 330; Created By: aklimaj
R23 R24 = 30838: SMT Resistor; Manufacturer: Stackpole Electronics Inc; Mfr Part Description: RES 604 Ohm ±1% 1/16W 0402 (1005 Metric); Mfr Part Number: RMCF0402FT604R; Database Table Name: Parts; Power_Rating: 0.063W, 1/16W; Temperature Coeff: ±100ppm/degC; Tolerance: ±1%; Updated By: aklimaj; Component Kind: Standard; ComponentLink1Description: Datasheet; ComponentLink1URL: https://www.seielect.com/catalog/sei-rmcf_rmcp.pdf; ComponentLink2Description: Supplier Part Details; ComponentLink2URL: https://www.digikey.com/product-detail/en/stackpole-electronics-inc/RMCF0402FT604R/RMCF0402FT604RCT-ND/6052942; Created_Date: 2020/07/23 10:53:25; ID: 30838; Library Name: Parts.DbLib; LifeCycle: New; Package_Case: 0402 (1005 Metric); Part_Type: Resistor; RoHS: Yes; Supplier: Digi-Key; Supplier Part Number: RMCF0402FT604RCT-ND; Temperature Range: -55C to +155C; Updated_Date: 2020/07/23 10:53:37; Value: 604; Created By: aklimaj
R25 = 30013: SMT Resistor; Manufacturer: TE Connectivity; Mfr Part Description: RES 10k Ohm ±5% 1/16W 0402 (1005 Metric); Mfr Part Number: CRG0402J10K/10; Database Table Name: Parts; Power_Rating: 0.063W,1/16W; Temperature Coeff: ±200ppm/°C; Tolerance: ±5%; Updated By: aklimaj; Component Kind: Standard; ComponentLink1Description: Datasheet; ComponentLink1URL: http://www.te.com/commerce/DocumentDelivery/DDEController?Action=srchrtrv&DocNm=1773204&DocType=DS&DocLang=English; ComponentLink2Description: Supplier Part Details; ComponentLink2URL: https://www.digikey.com/product-detail/en/te-connectivity-passive-product/CRG0402J10K-10/A106045CT-ND/3477682; Created_Date: 2017/07/12 15:59:23; ID: 30013; Library Name: Parts.DbLib; LifeCycle: New; Package_Case: 0402 (1005 Metric); Part_Type: Resistor; RoHS: Yes; Supplier: Digi-Key; Supplier Part Number: A106045CT-ND; Temperature Range: -55C to +125C; Updated_Date: 2017/07/12 16:25:29; Created By: aklimaj
R26-R29 = 30207: SMT Resistor; Manufacturer: Yageo; Mfr Part Description: RES 5.1K Ohm ±1% 1/16W 0402 (1005 Metric); Mfr Part Number: RC0402FR-075K1L; Database Table Name: Parts; Power_Rating: 0.063W, 1/16W; Temperature Coeff: ±100ppm/degC; Tolerance: ±1%; Updated By: aklimaj; Component Kind: Standard; ComponentLink1Description: Datasheet; ComponentLink1URL: http://www.yageo.com/documents/recent/PYu-RC_Group_51_RoHS_L_9.pdf; ComponentLink2Description: Supplier Part Details; ComponentLink2URL: https://www.digikey.com/product-detail/en/yageo/RC0402FR-075K1L/311-5.10KLRCT-ND/729571; Created_Date: 2018/05/21 18:16:47; ID: 30207; Library Name: Parts.DbLib; LifeCycle: New; Package_Case: 0402 (1005 Metric); Part_Type: Resistor; RoHS: Yes; Supplier: Digi-Key; Supplier Part Number: 311-5.10KLRCT-ND; Temperature Range: -55C to +155C; Updated_Date: 2018/10/19 21:38:02; Created By: aklimaj
S1 = 31020: Manufacturer: C&ampK; Mfr Part Description: TACT 4.5 X 4.5, 3.8 MM H, 2.5N,; Part_Type: Switch; Database Table Name: Parts; Updated By: aklimaj; Voltage_Rating: 0.05A @ 12VDC; Component Kind: Standard; ComponentLink1Description: Datasheet; ComponentLink1URL: https://www.ckswitches.com/media/2567/pts647.pdf; ComponentLink2Description: Supplier Part Details; ComponentLink2URL: https://www.digikey.com/products/en?keywords=PTS 647 SK38 SMTR2 LFS; Created_Date: 2021/03/25 22:33:30; ID: 31020; Library Name: Parts.DbLib; LifeCycle: New; Mfr Part Number: PTS 647 SK38 SMTR2 LFS; RoHS: Yes; Supplier: Digi-Key; Supplier Part Number: PTS647SK38SMTR2LFSTR-ND; Temperature Range: -20C to +70C; Updated_Date: 2021/03/25 22:42:03; Value: PTS 647 SK38 SMTR2 LFS; Created By: aklimaj
SP1 = 30093: AUDIO MAGNETIC XDCR 4-6V SMD; Manufacturer: Soberton Inc.; Current_Rating: 100mA; Database Table Name: Parts; Updated By: aklimaj; Voltage_Rating: 5V; Component Kind: Standard; ComponentLink1Description: Datasheet; ComponentLink1URL: https://www.soberton.com/wp-content/uploads/2019/02/ST-03CH-19-Feb-2019.pdf; ComponentLink2Description: Supplier Part Details; ComponentLink2URL: https://www.digikey.com/product-detail/en/ST-03CH/433-1007-1-ND; Created_Date: 2017/09/13 12:26:07; ID: 30093; Library Name: Parts.DbLib; LifeCycle: New; Mfr Part Description: AUDIO MAGNETIC XDCR 4-6V SMD; Mfr Part Number: ST-03CH; Part_Type: AUDIO; RoHS: Yes; Supplier: Digi-Key; Supplier Part Number: 433-1007-1-ND; Temperature Range: -30C to +70C; Updated_Date: 2020/06/01 14:49:47; Value: ST-03CH; Created By: aklimaj
TP4-TP8 TPAD1 TPAD2 = 30015: TEST_PAD; Mfr Part Description: Test Point; Database Table Name: Parts; Updated By: aklimaj; ComponentLink1Description: Datasheet; ComponentLink2Description: Supplier Part Details; Created_Date: 2017/07/13 09:26:35; ID: 30015; Library Name: Parts.DbLib; LifeCycle: New; Part_Type: Test Point; RoHS: Yes; Updated_Date: 2019/07/19 12:21:04; Created By: aklimaj
U6 = 30440: Manufacturer: U-Blox; Mfr Part Description: MOD RF RECEIVER GNSS 54LGA; Part_Type: MOD; Database Table Name: Parts; Notes: Was Mfr P/N ZED-F9P-00B-02; Updated By: aklimaj; Voltage_Rating: 2.7V ~ 3.6V; Component Kind: Standard; ComponentLink1Description: Datasheet; ComponentLink1URL: https://www.u-blox.com/sites/default/files/ZED-F9P_DataSheet_%28UBX-17051259%29.pdf; ComponentLink2Description: Supplier Part Details; ComponentLink2URL: https://www.digikey.com/products/en?keywords=ZED-F9P-02B; Created_Date: 2019/04/30 22:32:33; ID: 30440; Library Name: Parts.DbLib; LifeCycle: New; Mfr Part Number: ZED-F9P-02B; Package_Case: 54-SMD Module; RoHS: Yes; Supplier: Digi-Key; Supplier Part Number: 672-ZED-F9P-02BTR-ND; Temperature Range: -40C to +85C; Updated_Date: 2021/09/10 11:27:48; Value: ZED-F9P-02B; Created By: aklimaj
U7 = 30579: Manufacturer: STMicroelectronics; Mfr Part Description: ARM® Cortex®-M4 STM32F4 Microcontroller IC 32-Bit 100MHz 512KB (512K x 8) FLASH 48-UFQFPN (7x7); Part_Type: IC; Database Table Name: Parts; Updated By: aklimaj; Component Kind: Standard; ComponentLink1Description: Datasheet; ComponentLink1URL: http://www.st.com/content/ccc/resource/technical/document/datasheet/15/90/07/b3/8c/10/4e/95/DM00213872.pdf/files/DM00213872.pdf/jcr:content/translations/en.DM00213872.pdf; ComponentLink2Description: Supplier Part Details; ComponentLink2URL: https://www.digikey.com/product-detail/en/stmicroelectronics/STM32F412CEU6/497-18545-ND/6621739; Created_Date: 2019/10/28 15:48:57; ID: 30579; Library Name: Parts.DbLib; LifeCycle: New; Mfr Part Number: STM32F412CEU6; Package_Case: 48-UFQFN Exposed Pad; RoHS: Yes; Supplier: Digi-Key; Supplier Part Number: 497-18545-ND; Temperature Range: -40C to +85C (TA); Updated_Date: 2019/10/28 16:16:12; Value: STM32F412CEU6; Created By: aklimaj
U8 = 31087: Manufacturer: IQD Frequency Products; Mfr Part Description: XTAL OSC XO 8.0000MHZ HCMOS SMD; Part_Type: XTAL; Current_Rating: 10mA; Database Table Name: Parts; Updated By: aklimaj; Component Kind: Standard; ComponentLink1Description: Datasheet; ComponentLink1URL: https://www.iqdfrequencyproducts.com/products/pn/LFSPXO072801Reel.pdf; ComponentLink2Description: Supplier Part Details; ComponentLink2URL: https://www.digikey.com/en/products/detail/iqd-frequency-products/LFSPXO072801REEL/8633968; Created_Date: 2021/05/14 12:40:09; ID: 31087; Library Name: Parts.DbLib; LifeCycle: New; Mfr Part Number: LFSPXO072801REEL; Package_Case: 4-SMD, No Lead; RoHS: Yes; Supplier: Digi-Key; Supplier Part Number: 1923-1681-2-ND; Temperature Range: -40C to +85C; Updated_Date: 2021/05/14 12:44:40; Value: LFSPXO072801REEL; Created By: aklimaj
U9 = 31021: Manufacturer: TDK InvenSense; Mfr Part Description: MOTION SENSOR; Part_Type: MOTION; Database Table Name: Parts; Updated By: aklimaj; Component Kind: Standard; ComponentLink1Description: Datasheet; ComponentLink1URL: https://media.digikey.com/pdf/Data%20Sheets/TDK%20PDFs/ICM-42688-P_DS_Rev1.2.pdf; ComponentLink2Description: Supplier Part Details; ComponentLink2URL: https://www.digikey.com/products/en?keywords=ICM-42688-P; Created_Date: 2021/03/26 20:29:47; ID: 31021; Library Name: Parts.DbLib; LifeCycle: New; Mfr Part Number: ICM-42688-P; RoHS: Yes; Supplier: Digi-Key; Supplier Part Number: 1428-ICM-42688-PTR-ND; Updated_Date: 2021/03/26 20:53:04; Value: ICM-42688-P; Created By: aklimaj
U10 = 30801: Manufacturer: Bosch Sensortec; Mfr Part Description: SENSOR FLIPCORE/HALL SPI 12WLCSP; Part_Type: SENSOR; Current_Rating: 20mA; Database Table Name: Parts; Updated By: aklimaj; Voltage_Rating: 1.62V ~ 3.6V; Component Kind: Standard; ComponentLink1Description: Datasheet; ComponentLink1URL: https://ae-bst.resource.bosch.com/media/_tech/media/datasheets/BST-BMM150-DS001.pdf; ComponentLink2Description: Supplier Part Details; ComponentLink2URL: https://www.digikey.com/product-detail/en/bosch-sensortec/BMM150/828-1044-1-ND/4196683; Created_Date: 2020/06/17 17:06:43; ID: 30801; Library Name: Parts.DbLib; LifeCycle: New; Mfr Part Number: BMM150; Package_Case: 12-XFBGA, WLCSP; RoHS: Yes; Supplier: Digi-Key; Supplier Part Number: 828-1044-1-ND; Temperature Range: -40C to +85C (TA); Updated_Date: 2020/06/17 17:15:31; Value: BMM150; Created By: aklimaj
U11 = 30798: Manufacturer: Bosch Sensortec; Mfr Part Description: SENSOR PRESSURE ABS; Part_Type: SENSOR; Database Table Name: Parts; Updated By: aklimaj; Voltage_Rating: 1.65V ~ 3.6V; Component Kind: Standard; ComponentLink1Description: Datasheet; ComponentLink1URL: https://www.bosch-sensortec.com/media/boschsensortec/downloads/datasheets/bst-bmp388-ds001.pdf; ComponentLink2Description: Supplier Part Details; ComponentLink2URL: https://www.digikey.com/product-detail/en/bosch-sensortec/BMP388/828-1079-1-ND/8322640; Created_Date: 2020/06/16 12:00:45; ID: 30798; Library Name: Parts.DbLib; LifeCycle: New; Mfr Part Number: BMP388; Package_Case: 10-WFLGA; RoHS: Yes; Supplier: Digi-Key; Supplier Part Number: 828-1079-1-ND; Updated_Date: 2020/06/16 12:28:45; Value: BMP388; Created By: aklimaj
